# Altium schematic sheet CPLD.SchDoc(CPLD)
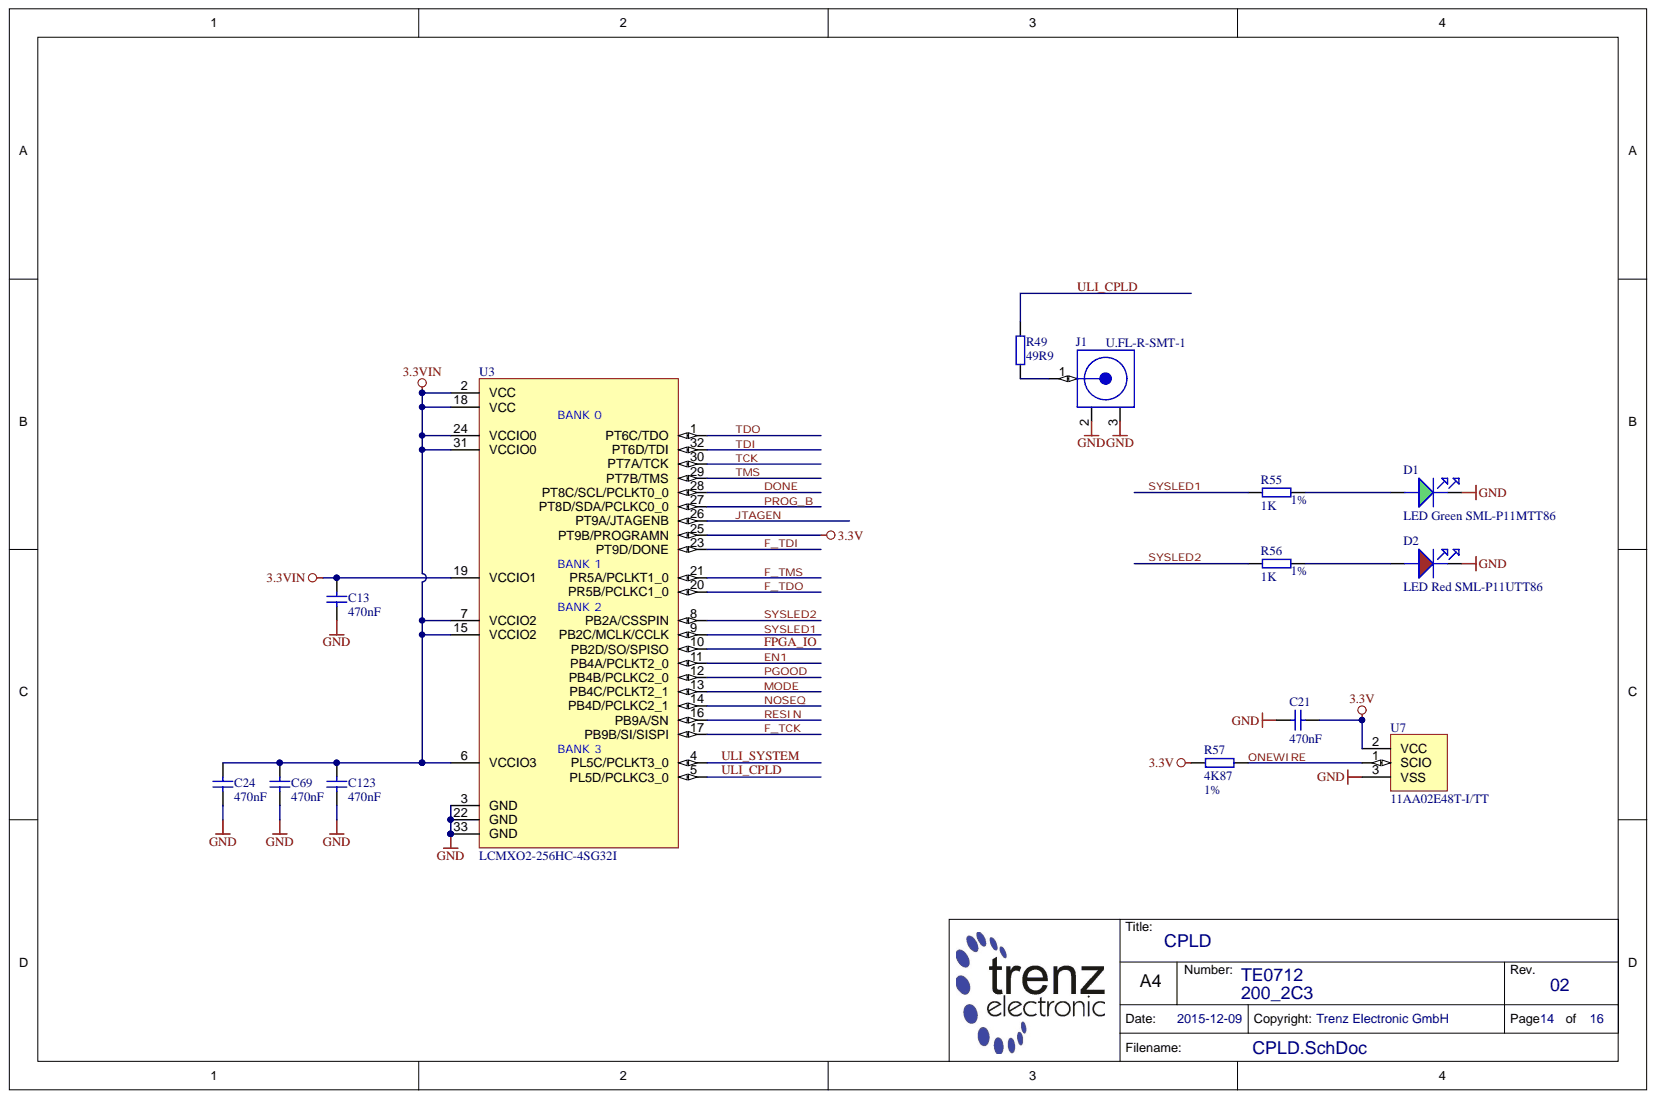
[diagram p0: CPLD.SchDoc]

## Parts
C13  470nF  [CAPC0603X33N]
C21  470nF  [CAPC0603X33N]
C24  470nF  [CAPC0603X33N]
C69  470nF  [CAPC0603X33N]
C123  470nF  [CAPC0603X33N]
D1  LED Green SML-P11MTT86  Database Table Name: LED  [LEDC1006X25N_GREEN]
D2  LED Red SML-P11UTT86  Database Table Name: LED  [LEDC1006X25N_RED]
J1  U.FL-R-SMT-1  [U.FL-R-SMT-1]
R49  49R9  [RESC1005X40N]
R55  1K  Tolerance: 1%  [RESC1005X40N]
R56  1K  Tolerance: 1%  [RESC1005X40N]
R57  4K87  Tolerance: 1%  [RESC1005X40N]
U3  LCMXO2-256HC-4SG32I  [PQFN50P500X500X60-33N]
U7  11AA02E48T-I/TT  [SOT95P237X112-3N]

## Nets
3.3V: C21.2 R57.1 U3.25(PT9B/PROGRAMN) U7.2
3.3VIN: C13.2 C24.2 C69.2 C123.2 U3.2(VCC) U3.6(VCCIO3) U3.7(VCCIO2) U3.15(VCCIO2) U3.18(VCC) U3.19(VCCIO1) U3.24(VCCIO0) U3.31(VCCIO0)
GND: C13.1 C21.1 C24.1 C69.1 C123.1 D1.K D2.K J1.2 J1.3 U3.3(GND) U3.22(GND) U3.33(GND) U7.3
DONE: U3.28(PT8C/SCL/PCLKT0_0)
EN1: U3.11(PB4A/PCLKT2_0)
F_TCK: U3.17(PB9B/SI/SISPI)
F_TDI: U3.23(PT9D/DONE)
F_TDO: U3.20(PR5B/PCLKC1_0)
F_TMS: U3.21(PR5A/PCLKT1_0)
FPGA_IO: U3.10(PB2D/SO/SPISO)
JTAGEN: U3.26(PT9A/JTAGENB)
MODE: U3.13(PB4C/PCLKT2_1)
NOSEQ: U3.14(PB4D/PCLKC2_1)
ONEWIRE: R57.2 U7.1
PGOOD: U3.12(PB4B/PCLKC2_0)
PROG_B: U3.27(PT8D/SDA/PCLKC0_0)
RESIN: U3.16(PB9A/SN)
SYSLED1: R55.2 U3.9(PB2C/MCLK/CCLK)
SYSLED2: R56.2 U3.8(PB2A/CSSPIN)
TCK: U3.30(PT7A/TCK)
TDI: U3.32(PT6D/TDI)
TDO: U3.1(PT6C/TDO)
TMS: U3.29(PT7B/TMS)
ULI_CPLD: R49.1 U3.5(PL5D/PCLKC3_0)
ULI_SYSTEM: U3.4(PL5C/PCLKT3_0)
NetD1_A: D1.A R55.1
NetD2_A: D2.A R56.1
NetJ1_1: J1.1 R49.2

## Library data of the parts
C13 C21 C24 C69 C123 = 24921: KON 470nF X5R 6.3V SMD 20%; Database Table Name: C_0603_0201; Mixed: SMT; Preferred: Yes; Value: 470n; AN: 24921; Case: 0603 (0201); Component Kind: Standard; Library Name: TE.DbLib; Technology: X5R; Tolerance: 20%; Voltage: 6.3V
D1 = 24211: LED Grün SML-P11MTT86 SMD; Mixed: SMT; Preferred: Yes; AN: 24211; Case: 1005 (0402); Component Kind: Standard; Library Name: TE.DbLib; HelpURL: B:\AD\adlib\datasheet\SML-P1
D2 = 24212: LED Rot SML-P11UTT86 SMD; Mixed: SMT; Preferred: Yes; AN: 24212; Case: 1005 (0402); Component Kind: Standard; Library Name: TE.DbLib; HelpURL: B:\AD\adlib\datasheet\SML-P1
J1 = 25605: Hirose Ultra Small Coaxial Connector; Database Table Name: CON_IO; Mixed: SMT; AN: 25605; Component Kind: Standard; H-ART: U.FL-R-SMT-1; Library Name: TE.DbLib; HelpURL: B:\AD\adlib\datasheet\U.FL
R49 = 23135: WID SMD 49R9 1% 0,063W; Database Table Name: R_1005_0402; Mixed: SMT; Preferred: Yes; Value: 49.9; AN: 23135; Case: 1005 (0402); Component Kind: Standard; Library Name: TE.DbLib
R55 R56 = 22752: WID SMD 1K 1% 0,063W; Database Table Name: R_1005_0402; H-ART: RC1005F102CS; Mixed: SMT; Preferred: Yes; AN: 22752; Case: 1005 (0402); Component Kind: Standard; Library Name: TE.DbLib; Value: 1K
R57 = 25921: WID SMD 4K87 1% 0,063W; Database Table Name: R_1005_0402; Mixed: SMT; Preferred: Yes; Value: 4.87k; AN: 25921; Case: 1005 (0402); Component Kind: Standard; Library Name: TE.DbLib
U3 = 26395: Database Table Name: PROG_LOGIC; Mixed: SMT; Preferred: Yes; AN: 26395; Case: QFN32; Component Kind: Standard; Description: LCMXO2-256HC-4SG32I; Library Name: TE.DbLib; Trenz-Stock: Yes; HelpURL: B:\AD\adlib\datasheet\MachXO2
U7 = 24735: Database Table Name: EEPROM; Mixed: SMT; Preferred: Yes; AN: 24735; Case: SOT23-3; Component Kind: Standard; Description: 11AA02E48T-I/TT; Library Name: TE.DbLib; Trenz-Stock: Yes; HelpURL: B:\AD\adlib\datasheet\11AA02E48
